# Altium schematic sheet Halfbridges.SchDoc
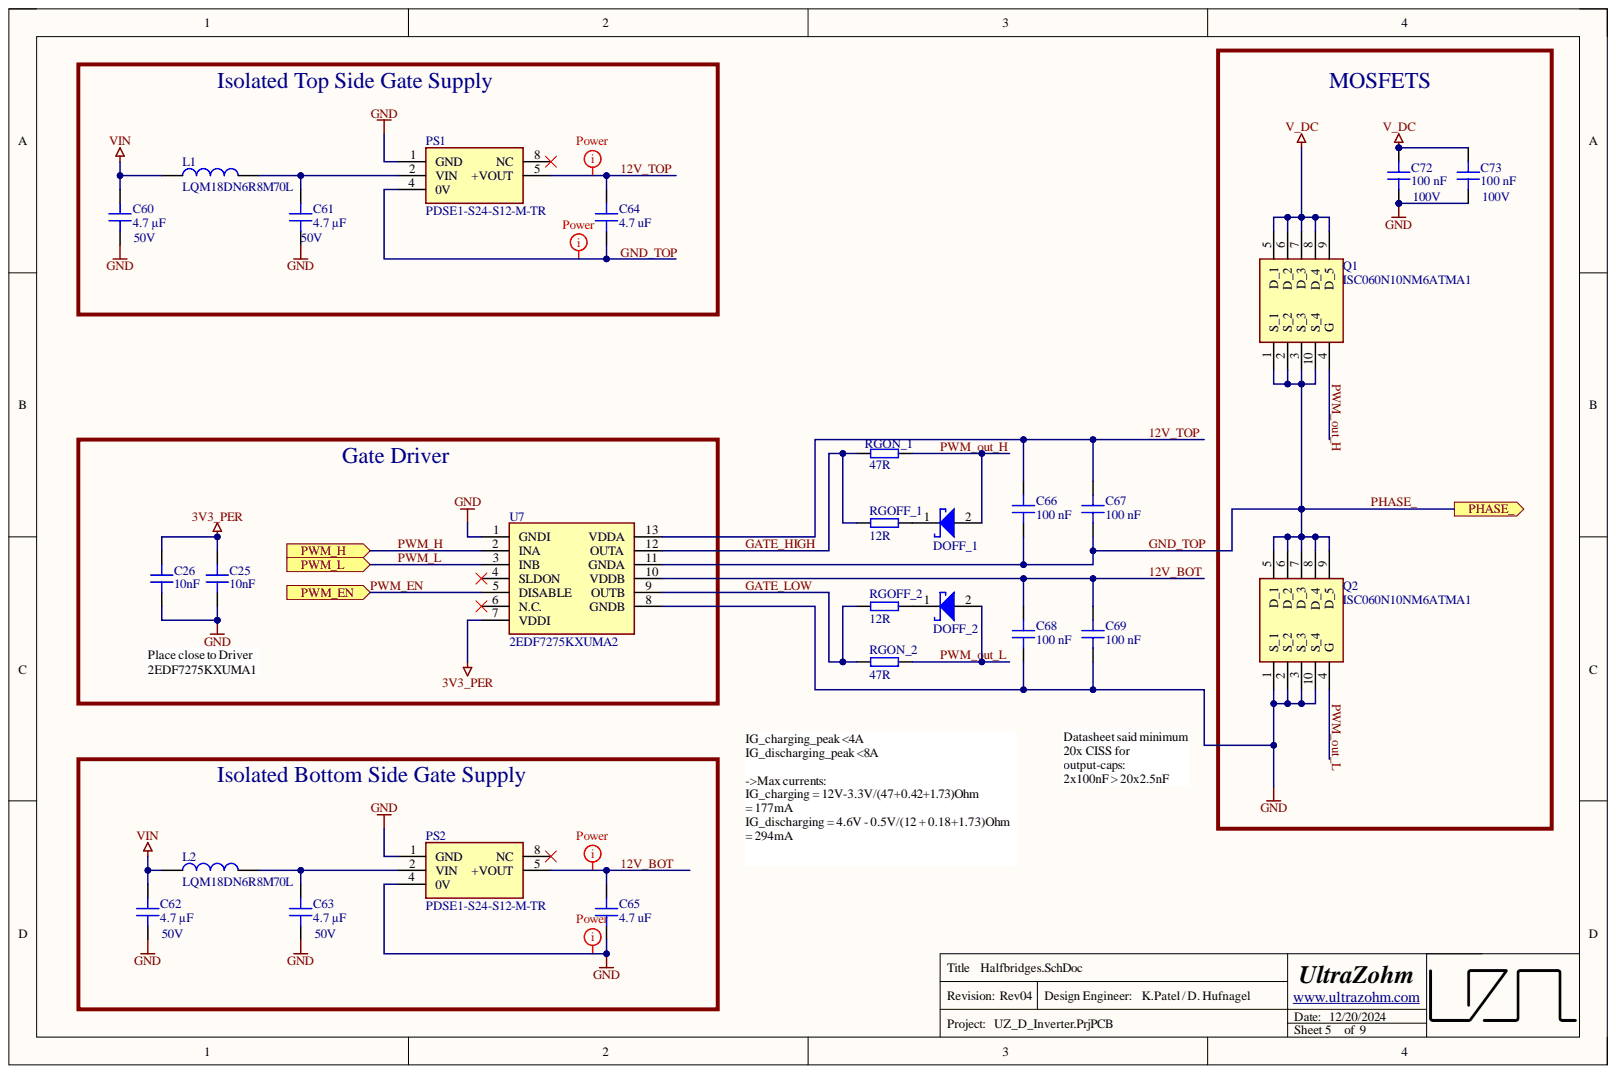
[diagram p0: Halfbridges.SchDoc]

## Parts
C25  10nF  [C_0603]
C26  10nF  [C_0603]
C60  4.7 µF  [C_0805]
C61  4.7 µF  [C_0805]
C62  4.7 µF  [C_0805]
C63  4.7 µF  [C_0805]
C64  4.7 uF  [C_0603]
C65  4.7 uF  [C_0603]
C66  100 nF  [C_0603]
C67  100 nF  [C_0603]
C68  100 nF  [C_0603]
C69  100 nF  [C_0603]
C72  100 nF  [C_0603]
C73  100 nF  [C_0603]
DOFF_1  BAT165E6327  [SOD2513X110N]  (value not drawn)
DOFF_2  BAT165E6327  [SOD2513X110N]  (value not drawn)
L1  LQM18DN6R8M70L  [INDC1608X95N]
L2  LQM18DN6R8M70L  [INDC1608X95N]
PS1  PDSE1-S24-S12-M-TR  [PDSE1S24S12MTR]
PS2  PDSE1-S24-S12-M-TR  [PDSE1S24S12MTR]
Q1  ISC060N10NM6ATMA1  [ISC060N10NM6ATMA1]
Q2  ISC060N10NM6ATMA1  [ISC060N10NM6ATMA1]
RGOFF_1  12R  [RESC1609X55N]
RGOFF_2  12R  [RESC1609X55N]
RGON_1  47R  [RESC1609X55N]
RGON_2  47R  [RESC1609X55N]
U7  2EDF7275KXUMA2  [2EDF7275KXUMA1]

## Ports
PHASE_, PWM_EN, PWM_H, PWM_L

## Nets
3V3_PER: C25.2 C26.2 U7.7(VDDI)
GND: C25.1 C26.1 C60.2 C61.2 C62.2 C63.2 C65.1 C68.1 C69.1 C72.1 C73.1 PS1.1(GND) PS2.1(GND) PS2.4(0V) Q2.1(S_1) Q2.2(S_2) Q2.3(S_3) Q2.10(S_4) U7.1(GNDI) U7.8(GNDB)
V_DC: C72.2 C73.2 Q1.5(D_1) Q1.6(D_2) Q1.7(D_3) Q1.8(D_4) Q1.9(D_5)
VIN: C60.1 C62.1 L1.1 L2.1
12V_BOT: C65.2 C68.2 C69.2 PS2.5(+VOUT) U7.10(VDDB)
12V_TOP: C64.2 C66.2 C67.2 PS1.5(+VOUT) U7.13(VDDA)
GATE_HIGH: RGOFF_1.1 RGON_1.1 U7.12(OUTA)
GATE_LOW: RGOFF_2.1 RGON_2.1 U7.9(OUTB)
GND_TOP: C64.1 C66.1 C67.1 PS1.4(0V) Q1.1(S_1) Q1.2(S_2) Q1.3(S_3) Q1.10(S_4) Q2.5(D_1) Q2.6(D_2) Q2.7(D_3) Q2.8(D_4) Q2.9(D_5) U7.11(GNDA) ; port PHASE_
PWM_EN: U7.5(DISABLE) ; port PWM_EN
PWM_H: U7.2(INA) ; port PWM_H
PWM_L: U7.3(INB) ; port PWM_L
PWM_out_H: DOFF_1.2 Q1.4(G) RGON_1.2
PWM_out_L: DOFF_2.2 Q2.4(G) RGON_2.2
NetC61_1: C61.1 L1.2 PS1.2(VIN)
NetC63_1: C63.1 L2.2 PS2.2(VIN)
NetDOFF_1_1: DOFF_1.1 RGOFF_1.2
NetDOFF_2_1: DOFF_2.1 RGOFF_2.2

Not connected: PS1 8(NC); PS2 8(NC); U7 4(SLDON) 6(N.C.)

## Library data of the parts
C25 C26 = CT41G-0603-2X1-50V-0.01μF-K(N): 50V 10nF 2X1 ±10%; Manufacturer: TORCH; Created from: KIlhan; Database Table Name: Capacitors - SMD; Type: Capacitor; Voltage Rating: 50 VDC; Component Kind: Standard; Component Type: Capacitor 0603; ComponentLink1Description: Datasheet; ComponentLink1URL: https://datasheet.lcsc.com/lcsc/1809291621_TORCH-CT41G-0603-2X1-50V-0-01-F-K-N_C136131.pdf; Created On: 05.07.2023 18:57:50; Device Package: 0603; Last Updated: 05.07.2023 18:57:50; LCSC Part Number: C136131; Library Name: user.DbLib; Live Cycle Status: 1; Manufacturer Part Number: CT41G-0603-2X1-50V-0.01μF-K(N); Mounting Type: SMD; Operating Temperature: -55 - 125°C; PartID: 130; Stock number: not stored; Supplier 1: LCSC; Supplier Part Number 1: C136131; Tolerance: 10 %; Value: 10nF
C60-C63 = C2012X7R1H475K125AC: Capacitor 0805, 50 VDC, 4.7 µF, X7R; Manufacturer: TDK; Created from: MGremer; Database Table Name: Capacitors - SMD; Tolerance: 10 %; Voltage Rating: 50 VDC; Component Kind: Standard; Component Type: Capacitor 0805; ComponentLink1Description: Datasheet; ComponentLink1URL: https://product.tdk.com/info/en/catalog/datasheets/mlcc_commercial_general_en.pdf; Created On: 20.12.2020 13:54:13; Device Package: 0805; Last Updated: 21.02.2024 14:33:48; LCSC Part Number: C437557; Library Name: user.DbLib; Live Cycle Status: 1; Manufacturer Part Number: C2012X7R1H475K125AC; Mounting Type: SMD; Operating Temperature: -55 - 125°C; PartID: 15; Series: C; Stock number: Labor SMD Container; Supplier 1: Mouser; Supplier 2: LCSC; Supplier Part Number 1: 810-C2012X7R475K125C; Supplier Part Number 2: C437557; Type: Capacitor; Value: 4.7 µF
C64 C65 = C1608JB1V475M080AC: Multilayer Ceramic Capacitors MLCC - SMD/SMT RECOMMENDED ALT 810-C1608X5R1V475K; Manufacturer: TDK; Created from: KPatel; Database Table Name: Capacitors - SMD; Tolerance: 20 %; Voltage Rating: 35 VDC; Component Kind: Standard; Component Type: Capacitor 0603; ComponentLink1Description: Datasheet; ComponentLink1URL: https://product.tdk.com/system/files/dam/doc/product/capacitor/ceramic/mlcc/catalog/mlcc_commercial_general_en.pdf; Created On: 12/20/2020 1:54:13 PM; Device Package: 0603; Last Updated: 12/14/2022 12:14:21 PM; LCSC Part Number: C3858973; Library Name: user.DbLib; Live Cycle Status: 1; Manufacturer Part Number: C1608JB1V475M080AC; Mounting Type: SMD; Operating Temperature: -55 to 125°C; PartID: 159; Series: X7R; Stock number: not stored; Supplier 1: LCSC; Supplier Part Number 1: C3858973; Type: Capacitor; Value: 4.7 uF
C66-C69 C72 C73 = C1608X7S2A104KT000N: 100V 100nF X7S ±10% 0603 Multilayer Ceramic Capacitors MLCC - SMD/SMT ROHS; Manufacturer: TDK; Created from: AGeiger; Database Table Name: Capacitors - SMD; Voltage Rating: 100 VDC; Component Kind: Standard; Component Type: Capacitor 0603; ComponentLink1Description: Datasheet; ComponentLink1URL: https://datasheet.lcsc.com/lcsc/2304140030_TDK-C1608X7S2A104KT000N_C343025.pdf; Created On: 20.12.2020 13:54:13; Device Package: 0603; Last Updated: 14.12.2022 12:14:21; LCSC Part Number: C343025; Library Name: user.DbLib; Live Cycle Status: 1; Manufacturer Part Number: C1608X7S2A104KT000N; Mounting Type: SMD; Operating Temperature: -55 to 125°C; PartID: 148; Series: X7R; Stock number: not stored; Supplier 1: LCSC; Supplier Part Number 1: C343025; Tolerance: 10 %; Type: Capacitor; Value: 100 nF
DOFF_1 DOFF_2 = BAT165E6327: Schottky Diode, 40 V, 750mA; Manufacturer: Infineon Technologies; Created from: Kpatel; Database Table Name: Diodes - Schottky; Forward Current: 0.75 A; Forward Voltage: 40V; Reverse Current: 50uA; Value: 40V; Component Kind: Standard; Component Type: Schottky Diode; ComponentLink1Description: Datasheet; ComponentLink1URL: https://www.mouser.de/datasheet/2/196/bat165series-12207.pdf; Created On: 6/11/2024 12:14:10 PM; Device Package: SOD-323-2; Last Updated: 7/30/2024 11:32:39 AM; Library Name: user.DbLib; Live Cycle Status: 1; Manufacturer Part Number: BAT165E6327; Mounting Type: SMD; Operating Temperature: -65 - 150 °C; PartID: 19; Series: BAT165; Stock number: not stored; Supplier 1: Mouser; Supplier 2: JLC; Supplier Part Number 1: 726-BAT165E6327; Supplier Part Number 2: C533629; Type: Schottky Diode
L1 L2 = LQM18DN6R8M70L: 6.8µH, 120mA, 0603, 740mOhm, 20%; Manufacturer: Murata; MPN: B82464G4154M; Created from: DHufnagel; Database Table Name: Inductances - SMD; DCR: 320m; MF: EPCOS / TDK; Rated Current: 0.85 A; Type: fixed inductor; Value: 6.8uH; Component Kind: Standard; Component Type: SMD fixed inductor; ComponentLink1Description: Datasheet; ComponentLink1URL: https://www.mouser.de/datasheet/2/281/1/JELF243B_0086-2298241.pdf; Created On: 19.07.2022 15:47:17; DC current: 120mA; Device Package: SMD; Last Updated: 15.06.2023 16:03:16; LCSC Part Number: C1881372; Library Name: user.DbLib; Live Cycle Status: 1; Manufacturer Part Number: LQM18DN6R8M70L; Mounting Type: SMD; Operating Temperature: -40 - 125°C; PartID: 10; Series: LQM18DN_70; Stock number: not stored; Supplier 1: Mouser; Supplier 2: Digi-Key; Supplier Part Number 1: 81-LQM18DN6R8M70L; Supplier Part Number 2: 490-LQM18DN6R8M70LCT-ND
PS1 PS2 = PDSE1-S24-S12-M-TR: Isolated, 21.6 -26.4Vin, 12V out, 1W, 84mA out; Manufacturer: CUI Inc.; Created from: DHufnagel; Database Table Name: Power Supply - Module; Component Kind: Standard; Component Type: Isolated DC-DC Converter; ComponentLink1Description: Datasheet; ComponentLink1URL: https://www.mouser.de/datasheet/2/670/pdse1_m-1596038.pdf; Created On: 19.07.2022 14:22:00; Device Package: SMT-5; Last Updated: 05.07.2023 17:07:31; LCSC Part Number: C6730719; Library Name: user.DbLib; Live Cycle Status: 1; Manufacturer Part Number: PDSE1-S24-S12-M-TR; Mounting Type: SMT; Operating Temperature: -40 - 105°C; PartID: 21; Series: PDSE1-M; Stock number: not stored; Supplier 1: Mouser; Supplier 2: Digi-Key; Supplier Part Number 1: 490-PDSE1-S24-S12MTR; Supplier Part Number 2: 102-PDSE1-S24-S12-M-TRCT-ND; Type: isolated DC-DC Converter; Value: 1 W
Q1 Q2 = ISC060N10NM6ATMA1: Power MOSFET, n-Channel, 97A, 100V; Manufacturer: Infineon Technologies; Created from: DHufnagel; Database Table Name: MOSFET - N-Channel; Value: 100V, 97A; Component Kind: Standard; Component Type: Power MOSFET; ComponentLink1Description: Datasheet; ComponentLink1URL: https://www.mouser.de/datasheet/2/196/Infineon_ISC060N10NM6_DataSheet_v02_00_EN-2942434.pdf; Created On: 28.06.2022 07:55:49; Device Package: PG-TDSON-8 FL; Last Updated: 21.02.2024 15:31:45; LCSC Part Number: C3288847; Library Name: user.DbLib; Live Cycle Status: 1; Manufacturer Part Number: ISC060N10NM6ATMA1; Mounting Type: SMD; Operating Temperature: -55 - 175°C; PartID: 3; Series: ISC060N10; Stock number: not stored; Supplier 1: Mouser; Supplier 2: RS-online; Supplier 3: LCSC; Supplier Part Number 1: 726-ISC060N10NM6ATMA; Supplier Part Number 2: 235-4869; Supplier Part Number 3: C3288847; Type: Power MOSFET
RGOFF_1 RGOFF_2 = RT0603WRC0712RL: SMD resistor E24 series; Manufacturer: YAGEO; Created from: K.Patel; Database Table Name: Resistors - SMD; Tolerance: 0.05%; Component Kind: Standard; Component Type: SMD resistor; ComponentLink1Description: Datasheet; ComponentLink1URL: https://wmsc.lcsc.com/wmsc/upload/file/pdf/v2/lcsc/2403111543_YAGEO-RT0603WRC0712RL_C1720876.pdf; Created On: 8/14/2024 5:03:13 PM; Device Package: 0603; Last Updated: 8/14/2024 5:03:13 PM; LCSC Part Number: C1720876; Library Name: user.DbLib; Live Cycle Status: 1; Manufacturer Part Number: RT0603WRC0712RL; Mounting Type: SMD; PartID: 349; Supplier 1: Mouser; Supplier 2: LCSC; Supplier Part Number 1: C1720876; Supplier Part Number 2: C1720876; Type: Resistor; Value: 12R
RGON_1 RGON_2 = RT0603WRC0747RL: SMD resistor E24 series; Manufacturer: YAGEO; Created from: K.Patel; Database Table Name: Resistors - SMD; Tolerance: 0.05%; Component Kind: Standard; Component Type: SMD resistor; ComponentLink1Description: Datasheet; ComponentLink1URL: https://wmsc.lcsc.com/wmsc/upload/file/pdf/v2/lcsc/2403111541_YAGEO-RT0603WRC0747RL_C1718809.pdf; Created On: 8/14/2024 5:03:13 PM; Device Package: 0603; Last Updated: 8/14/2024 5:03:13 PM; LCSC Part Number: C1718809; Library Name: user.DbLib; Live Cycle Status: 1; Manufacturer Part Number: RT0603WRC0747RL; Mounting Type: SMD; PartID: 350; Supplier 1: Mouser; Supplier 2: LCSC; Supplier Part Number 1: C1718809; Supplier Part Number 2: C1718809; Type: Resistor; Value: 47R
U7 = 2EDF7275KXUMA2: Gate Driver  8A, 1.5 kV, daul channel isolated gate driver; Manufacturer: Infineon Technologies; Created from: AGeiger; Database Table Name: MOSFET - Gate Drivers; Component Kind: Standard; Component Type: Gate Driver; ComponentLink1Description: Datasheet; ComponentLink1URL: https://datasheet.lcsc.com/lcsc/2202151130_Infineon-Technologies-2EDF7275KXUMA2_C2975252.pdf; Created On: 21.02.2024 16:40:21; Device Package: PG-TFLGA-13-1; Last Updated: 21.02.2024 16:40:21; LCSC Part Number: C2975252; Library Name: user.DbLib; Live Cycle Status: 1; Manufacturer Part Number: 2EDF7275KXUMA2; Mounting Type: SMD; Operating Temperature: -40 - 125°C; PartID: 7; Stock number: not stored; Supplier 1: LCSC; Supplier Part Number 1: C2975252; Type: Gate Driver
